# Altium schematic sheet LED_backlight.SchDoc
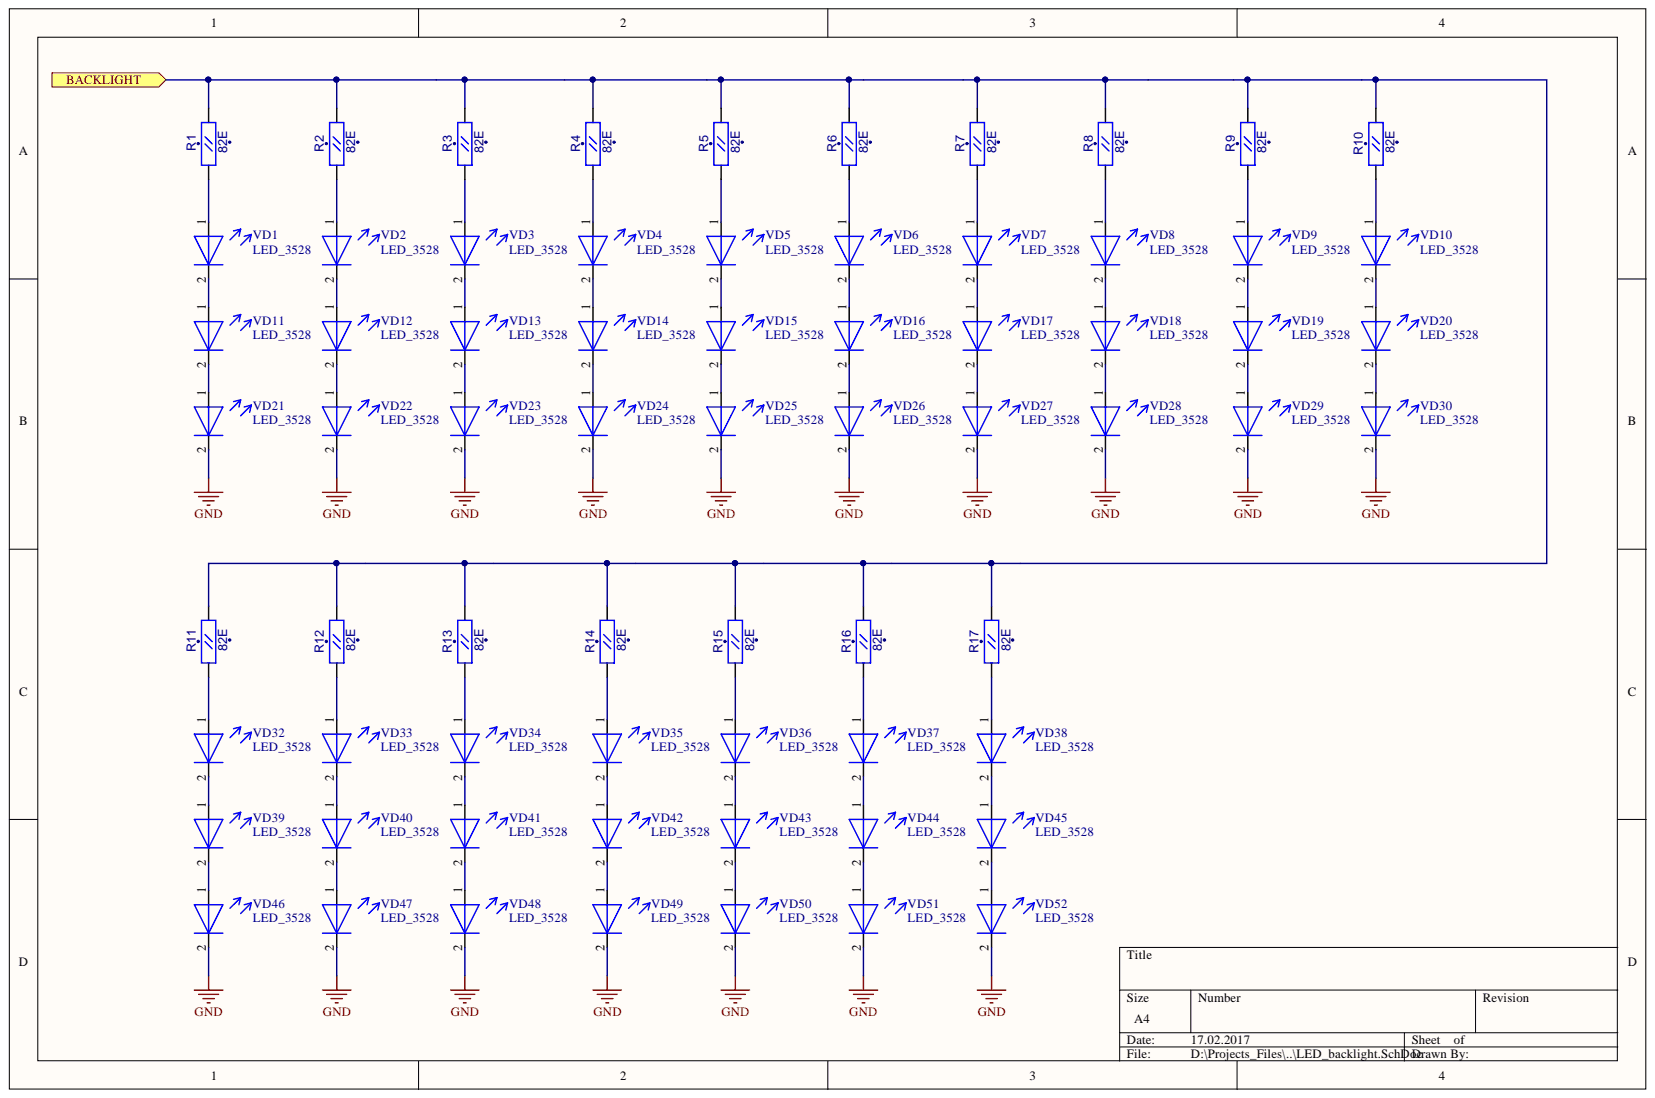
[diagram p0: LED_backlight.SchDoc]

## Parts
R1  82E  [RC0805]
R2  82E  [RC0805]
R3  82E  [RC0805]
R4  82E  [RC0805]
R5  82E  [RC0805]
R6  82E  [RC0805]
R7  82E  [RC0805]
R8  82E  [RC0805]
R9  82E  [RC0805]
R10  82E  [RC0805]
R11  82E  [RC0805]
R12  82E  [RC0805]
R13  82E  [RC0805]
R14  82E  [RC0805]
R15  82E  [RC0805]
R16  82E  [RC0805]
R17  82E  [RC0805]
VD1  LED_3528  [3528LED]
VD2  LED_3528  [3528LED]
VD3  LED_3528  [3528LED]
VD4  LED_3528  [3528LED]
VD5  LED_3528  [3528LED]
VD6  LED_3528  [3528LED]
VD7  LED_3528  [3528LED]
VD8  LED_3528  [3528LED]
VD9  LED_3528  [3528LED]
VD10  LED_3528  [3528LED]
VD11  LED_3528  [3528LED]
VD12  LED_3528  [3528LED]
VD13  LED_3528  [3528LED]
VD14  LED_3528  [3528LED]
VD15  LED_3528  [3528LED]
VD16  LED_3528  [3528LED]
VD17  LED_3528  [3528LED]
VD18  LED_3528  [3528LED]
VD19  LED_3528  [3528LED]
VD20  LED_3528  [3528LED]
VD21  LED_3528  [3528LED]
VD22  LED_3528  [3528LED]
VD23  LED_3528  [3528LED]
VD24  LED_3528  [3528LED]
VD25  LED_3528  [3528LED]
VD26  LED_3528  [3528LED]
VD27  LED_3528  [3528LED]
VD28  LED_3528  [3528LED]
VD29  LED_3528  [3528LED]
VD30  LED_3528  [3528LED]
VD32  LED_3528  [3528LED]
VD33  LED_3528  [3528LED]
VD34  LED_3528  [3528LED]
VD35  LED_3528  [3528LED]
VD36  LED_3528  [3528LED]
VD37  LED_3528  [3528LED]
VD38  LED_3528  [3528LED]
VD39  LED_3528  [3528LED]
VD40  LED_3528  [3528LED]
VD41  LED_3528  [3528LED]
VD42  LED_3528  [3528LED]
VD43  LED_3528  [3528LED]
VD44  LED_3528  [3528LED]
VD45  LED_3528  [3528LED]
VD46  LED_3528  [3528LED]
VD47  LED_3528  [3528LED]
VD48  LED_3528  [3528LED]
VD49  LED_3528  [3528LED]
VD50  LED_3528  [3528LED]
VD51  LED_3528  [3528LED]
VD52  LED_3528  [3528LED]

## Ports
BACKLIGHT

## Nets
GND: VD21.2 VD22.2 VD23.2 VD24.2 VD25.2 VD26.2 VD27.2 VD28.2 VD29.2 VD30.2 VD46.2 VD47.2 VD48.2 VD49.2 VD50.2 VD51.2 VD52.2
BACKLIGHT: R1.2 R2.2 R3.2 R4.2 R5.2 R6.2 R7.2 R8.2 R9.2 R10.2 R11.2 R12.2 R13.2 R14.2 R15.2 R16.2 R17.2 ; port BACKLIGHT
NetR1_1: R1.1 VD1.1
NetR2_1: R2.1 VD2.1
NetR3_1: R3.1 VD3.1
NetR4_1: R4.1 VD4.1
NetR5_1: R5.1 VD5.1
NetR6_1: R6.1 VD6.1
NetR7_1: R7.1 VD7.1
NetR8_1: R8.1 VD8.1
NetR9_1: R9.1 VD9.1
NetR10_1: R10.1 VD10.1
NetR11_1: R11.1 VD32.1
NetR12_1: R12.1 VD33.1
NetR13_1: R13.1 VD34.1
NetR14_1: R14.1 VD35.1
NetR15_1: R15.1 VD36.1
NetR16_1: R16.1 VD37.1
NetR17_1: R17.1 VD38.1
NetVD1_2: VD1.2 VD11.1
NetVD2_2: VD2.2 VD12.1
NetVD3_2: VD3.2 VD13.1
NetVD4_2: VD4.2 VD14.1
NetVD5_2: VD5.2 VD15.1
NetVD6_2: VD6.2 VD16.1
NetVD7_2: VD7.2 VD17.1
NetVD8_2: VD8.2 VD18.1
NetVD9_2: VD9.2 VD19.1
NetVD10_2: VD10.2 VD20.1
NetVD11_2: VD11.2 VD21.1
NetVD12_2: VD12.2 VD22.1
NetVD13_2: VD13.2 VD23.1
NetVD14_2: VD14.2 VD24.1
NetVD15_2: VD15.2 VD25.1
NetVD16_2: VD16.2 VD26.1
NetVD17_2: VD17.2 VD27.1
NetVD18_2: VD18.2 VD28.1
NetVD19_2: VD19.2 VD29.1
NetVD20_2: VD20.2 VD30.1
NetVD32_2: VD32.2 VD39.1
NetVD33_2: VD33.2 VD40.1
NetVD34_2: VD34.2 VD41.1
NetVD35_2: VD35.2 VD42.1
NetVD36_2: VD36.2 VD43.1
NetVD37_2: VD37.2 VD44.1
NetVD38_2: VD38.2 VD45.1
NetVD39_2: VD39.2 VD46.1
NetVD40_2: VD40.2 VD47.1
NetVD41_2: VD41.2 VD48.1
NetVD42_2: VD42.2 VD49.1
NetVD43_2: VD43.2 VD50.1
NetVD44_2: VD44.2 VD51.1
NetVD45_2: VD45.2 VD52.1

## Library data of the parts
R1-R17 = RC0805: Component Kind: Standard; Description: RC0805; Value: 82E; Library Name: gprs_modem_2.SchLib
VD1-VD30 VD32-VD52 = 3528LED: Component Kind: Standard; Value: LED_3528; Library Name: VHF.SchLib
